# Altium schematic sheet PS.SchDoc(PS)
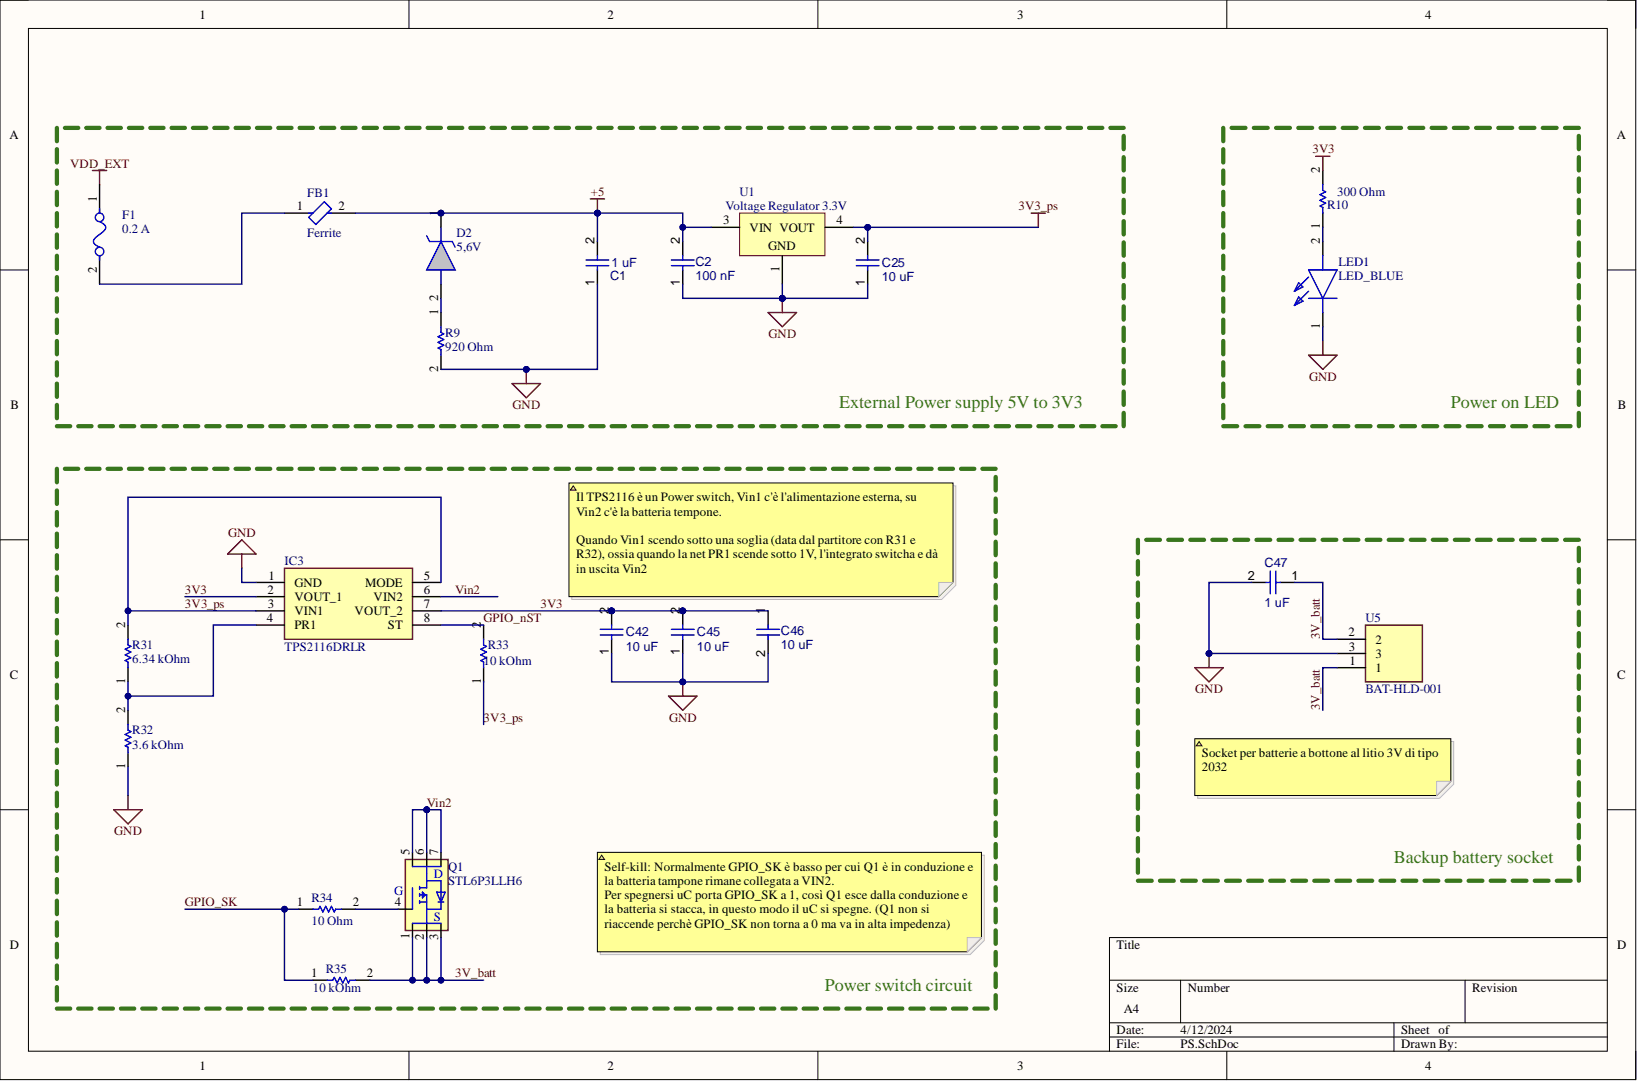
[diagram p0: PS.SchDoc]

## Parts
C1  1 uF
C2  100 nF
C25  10 uF
C42  10 uF
C45  10 uF
C46  10 uF
C47  1 uF
D2  5,6V
F1  0.2 A
FB1  Ferrite
IC3  TPS2116DRLR
LED1  LED_BLUE
Q1  STL6P3LLH6
R9  920 Ohm
R10  300 Ohm
R31  6.34 kOhm
R32  3.6 kOhm
R33  10 kOhm
R34  10 Ohm
R35  10 kOhm
U1  Voltage Regulator 3.3V
U5  BAT-HLD-001

## Nets
+5: C1.2 C2.2 D2.1 FB1.2 U1.3
GND: C1.1 C2.1 C25.1 C42.1 C45.1 C46.2 C47.2 IC3.1(GND) LED1.1 R9.2 R32.1 U1.1 U5.3
VDD_EXT: F1.1
3V3: C42.2 C45.2 C46.1 IC3.2(VOUT_1) IC3.7(VOUT_2) R10.2
3V3_ps: C25.2 IC3.3(VIN1) IC3.5(MODE) R31.2 R33.1 U1.4
3V_batt: C47.1 Q1.1 Q1.2 Q1.3(S) R35.2 U5.1 U5.2
GPIO_nST: IC3.8(ST) R33.2
GPIO_SK: R34.1 R35.1
Vin2: IC3.6(VIN2) Q1.5 Q1.6 Q1.7(D)
NetD2_2: D2.2 R9.1
NetF1_2: F1.2 FB1.1
NetIC3_4: IC3.4(PR1) R31.1 R32.2
NetLED1_2: LED1.2 R10.1
NetQ1_4: Q1.4 R34.2

## Library data of the parts
C1 C47 = CMP-001-00085-2: VJ0805Y105KXXTW1BC; Tech: SMD; Component Kind: Standard; ComponentLink1Description: Website; ComponentLink1URL: https://www.mouser.it/ProductDetail/Vishay-Vitramon/VJ0805V105MXQPW1BC?qs=wtJBjE46HQsbbuoG%2F6UKXw%3D%3D; Package: 0805; Library Name: EMEA- Squadra Corse PoliTO-  Politecnico di Torino
C2 = CMP-001-00085-2: VJ0805Y104KBABE31; Tech: SMD; Component Kind: Standard; ComponentLink1Description: Website; Package: 0805; Library Name: EMEA- Squadra Corse PoliTO-  Politecnico di Torino
C25 = CMP-001-00085-2: GRM21BR71A106KA73K; Tech: SMD; Component Kind: Standard; ComponentLink1Description: Website; ComponentLink1URL: https://www.mouser.it/ProductDetail/Vishay-Vitramon/VJ0805G106MXQTW1BC?qs=PqoDHHvF64%2FiIFVCZpIM5w%3D%3D; Package: 0805; Library Name: EMEA- Squadra Corse PoliTO-  Politecnico di Torino
C42 C45 C46 = CMP-001-00085-2: TMCP0J106MTRF; Tech: SMD; Component Kind: Standard; ComponentLink1Description: Website; ComponentLink1URL: https://www.mouser.it/ProductDetail/Vishay-Vitramon/VJ0805G106MXQTW1BC?qs=PqoDHHvF64%2FiIFVCZpIM5w%3D%3D; Package: 0805; Library Name: EMEA- Squadra Corse PoliTO-  Politecnico di Torino
D2 = CMP-095-000000-1: DDZ5V6ASF-7; Manufacturer_Name: Diodes Inc.; Geometry.Height: 0.75mm; Component Kind: Standard; Datasheet Link: https://componentsearchengine.com/Datasheets/1/DDZ5V6ASF-7.pdf; Design Item ID: DDZ5V6ASF-7; Manufacturer_Part_Number: DDZ5V6ASF-7; Mouser Part Number: 621-DDZ5V6ASF-7; Mouser Price/Stock: https://www.mouser.com/Search/Refine.aspx?Keyword=621-DDZ5V6ASF-7; Source: DDZ5V6ASF-7.SchLib; Library Name: EMEA- Squadra Corse PoliTO-  Politecnico di Torino
F1 = CMP-08605-000096-1: 0466.200NRHF; Approvals: UL; Current: 200mA; DC Cold Resistance: 1.16R; Fuse Type: Board Mount (Cartridge Style Excluded); Power Dissipation: 50.9mW; Voltage Rating (AC): 125V; Case Code (Imperial): 1206; Case Code (Metric): 3216; Case/Package: SMD/SMT; Component Kind: Standard; Current Rating: 200mA; Depth: 1.52mm; Height: 0.023inch; Housing Material: Ceramic; Lead Free: Contains Lead; Length: 3.18mm; Library Name: Altium Content Vault; Max Operating Temperature: 90°C; Min Operating Temperature: -55°C; Mount: Surface Mount; Number of Pins: 2; Package Quantity: 5000; Packaging: Tape and Reel; Radiation Hardening: No; RoHS Compliant: Yes; Series: SlimLine™ 466; Voltage Rating (DC): 125V; Width: 0.06inch
FB1 = CMP-06046-002291-1: BLM31KN102SH1L; DC Resistance (DCR): 75mR; Max Current Rating: 2A; Number of Lines: 1; Case Code (Imperial): 1206; Case Code (Metric): 3216; Case/Package: 1206; Component Kind: Standard; Library Name: Altium Content Vault; Max Operating Temperature: 125°C; Min Operating Temperature: -55°C; Mount: Surface Mount; Packaging: Cut Tape; Ratings: AEC-Q200; RoHS Compliant: Yes
IC3 = CMP-030-000055-1: Power switch; Manufacturer_Name: Texas Instruments; Arrow Part Number: TPS2116DRLR; Arrow Price/Stock: https://www.arrow.com/en/products/tps2116drlr/texas-instruments?region=nac; Component Kind: Standard; Datasheet Link: https://www.ti.com/lit/gpn/tps2116; Design Item ID: TPS2116DRLR; Height: 0.6mm; Manufacturer_Part_Number: TPS2116DRLR; Mouser Part Number: 595-TPS2116DRLR; Mouser Price/Stock: https://www.mouser.co.uk/ProductDetail/Texas-Instruments/TPS2116DRLR?qs=aP1CjGhiNiGo3XAyXcVPaQ%3D%3D; Source: SamacSys.SchLib; Library Name: EMEA- Squadra Corse PoliTO-  Politecnico di Torino
LED1 = CMP-031-000016-1: APT2012LVBC_D; Manufacturer_Name: Kingbright; Manufacturer_Part_Number: APT2012LVBC/D; Tech: SMD; Arrow Part Number: APT2012LVBC/D; Arrow Price/Stock: https://www.arrow.com/en/products/apt2012lvbcd/kingbright; Component Kind: Standard; ComponentLink1Description: Website; ComponentLink1URL: https://www.mouser.it/ProductDetail/Kingbright/APT2012LVBC-D?qs=6oMev5NRZMGErJYT6KZLXg%3D%3D; Datasheet Link: https://componentsearchengine.com/Datasheets/1/APT2012LVBC_D.pdf; Design Item ID: APT2012LVBC_D; Height: 0.85mm; Mouser Part Number: 604-APT2012LVBCD; Mouser Price/Stock: https://www.mouser.co.uk/ProductDetail/Kingbright/APT2012LVBC-D?qs=6oMev5NRZMGErJYT6KZLXg%3D%3D; Package: 0805; Source: SamacSys.SchLib; Library Name: EMEA- Squadra Corse PoliTO-  Politecnico di Torino
Q1 = CMP-00002-3: P-channel 30 V, 0.024 Ohm typ., 6 A STripFET H6 Power MOSFET in a PowerFLAT 3.3 x 3.3 package; Continuous Drain Current (ID): 6A; Drain to Source Voltage (Vdss): 30V; Input Capacitance: 1.45nF; Max Power Dissipation: 2.9W; Rds On Max: 30mR; Component Kind: Standard; Lead Free: Lead Free; Mounting Technology: Surface Mount; Packaging: Cut Tape; Pins: 8; RoHS Compliant: Yes; Series: STripFET™ H6; Library Name: EMEA- Squadra Corse PoliTO-  Politecnico di Torino
R9 = CMP-00010-3: RT0603DRE07920RL; Component Kind: Standard; Library Name: EMEA- Squadra Corse PoliTO-  Politecnico di Torino
R10 = CMP-009-00179-4: CRCW0603300RFKEA; Tech: SMD; Component Kind: Standard; ComponentLink1Description: Website; ComponentLink1URL: https://www.mouser.it/ProductDetail/Vishay-Dale/CRCW0603300RFKEA?qs=SNwsiWikH8qfoKtotWEteQ%3D%3D; Package: 0603; Library Name: EMEA- Squadra Corse PoliTO-  Politecnico di Torino
R31 = CMP-00010-3: CRCW06036K34FKEA; Component Kind: Standard; Library Name: EMEA- Squadra Corse PoliTO-  Politecnico di Torino
R32 = CMP-00010-3: CRCW06033K60FKEA; Component Kind: Standard; Library Name: EMEA- Squadra Corse PoliTO-  Politecnico di Torino
R33 R35 = CMP-00010-3: CRCW060310K0FKEA; Component Kind: Standard; Library Name: EMEA- Squadra Corse PoliTO-  Politecnico di Torino
R34 = CMP-00010-3: RC0603FR-7W10RL; Component Kind: Standard; Library Name: EMEA- Squadra Corse PoliTO-  Politecnico di Torino
U1 = CMP-0244-00089-1: LD1117DT33CTR; Tech: SMD; Component Kind: Standard; ComponentLink1Description: Manufacturer URL; ComponentLink1URL: http://www.st.com; ComponentLink2Description: Datasheet; ComponentLink2URL: http://www.st.com/internet/com/TECHNICAL_RESOURCES/TECHNICAL_LITERATURE/DATASHEET/CD00000544.pdf; ComponentLink3Description: Website; ComponentLink3URL: https://www.mouser.it/ProductDetail/STMicroelectronics/LD1117DT33CTR?qs=rUxiu3TV3%2FNm%2FOyb7vJzpg%3D%3D; DatasheetVersion: 1/11/2011; Library Name: Altium Content Vault; PackageDescription: 3-Pin Transistor Outline Package 2.28 mm Pitch, 9.7 mm Lead Span (DPAK); PackageReference: TO-252; PackageVersion: 2011
U5 = CMP-145-000000-1: Coin Cell Battery Holders Linx CR2032 Battery Holder; Manufacturer_Name: Linx Technologies; Component Kind: Standard; Datasheet Link: https://www.mouser.com/ds/2/238/bat-hld-001-220194.pdf; Design Item ID: BAT-HLD-001; Height: 4mm; Manufacturer_Part_Number: BAT-HLD-001; Mouser Part Number: 712-BAT-HLD-001; Mouser Price/Stock: https://www.mouser.co.uk/ProductDetail/Linx-Technologies/BAT-HLD-001?qs=K5ta8V%252BWhta7hbVGfm4dqA%3D%3D; Source: SamacSys.SchLib; Library Name: EMEA- Squadra Corse PoliTO-  Politecnico di Torino
